# Altium schematic sheet DEVICE.SchDoc(DEVICE)
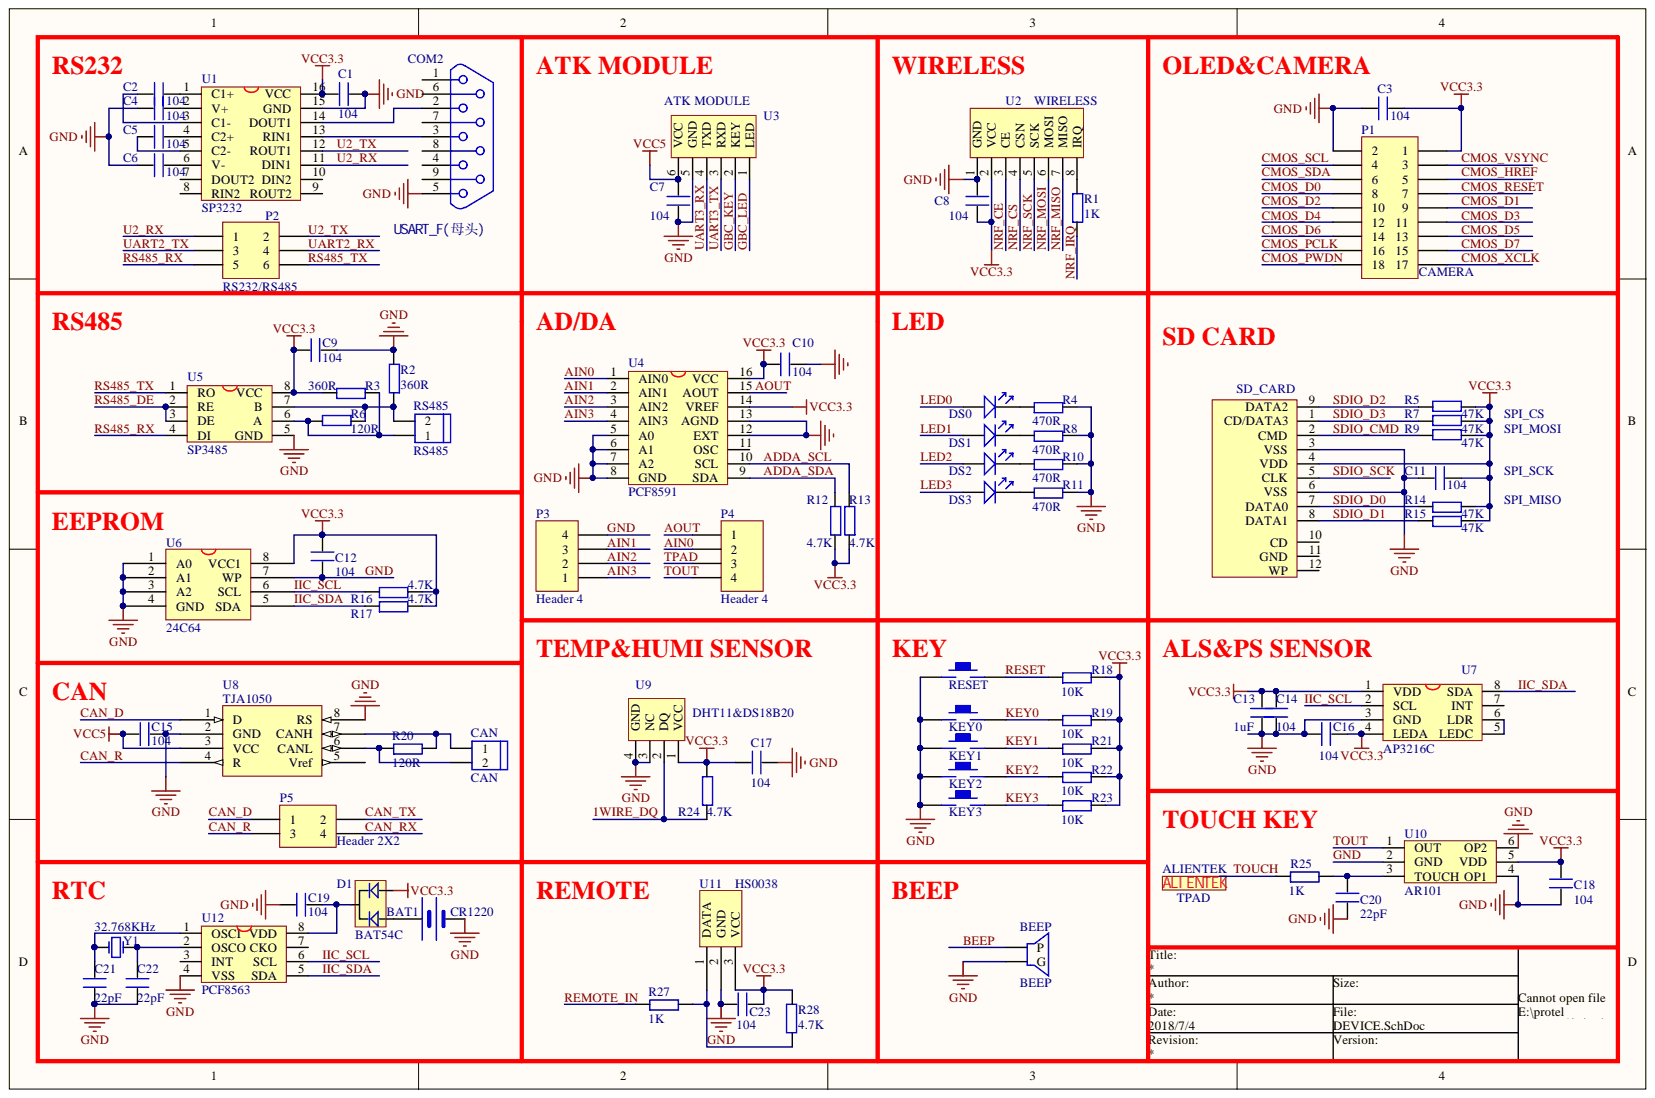
[diagram p0: DEVICE.SchDoc]

## Parts
BAT1  CR1220  [BATM]
BEEP  BEEP  [BELL-5]
C1  104  [0603P]
C2  104  [0603P]
C3  104  [0603P]
C4  104  [0603P]
C5  104  [0603P]
C6  104  [0603P]
C7  104  [0603P]
C8  104  [0603P]
C9  104  [0603P]
C10  104  [0603P]
C11  104  [0603P]
C12  104  [0603P]
C13  1uF  [0603P]
C14  104  [0603P]
C15  104  [0603P]
C16  104  [0603P]
C17  104  [0603P]
C18  104  [0603P]
C19  104  [0603P]
C20  22pF  [0603P]
C21  22pF  [0603P]
C22  22pF  [0603P]
C23  104  [0603P]
CAN  CAN  [HEAD2L-0.8]
COM2  USART_F(母头)  [DB9]
D1  BAT54C  [SOT-23]
KEY0  KEY_M  [KEY_M]  (value not drawn)
KEY1  KEY_M  [KEY_M]  (value not drawn)
KEY2  KEY_M  [KEY_M]  (value not drawn)
KEY3  KEY_M  [KEY_M]  (value not drawn)
LED1  DS0  [SMDLED-0805]
LED2  DS1  [SMDLED-0805]
LED3  DS2  [SMDLED-0805]
LED4  DS3  [SMDLED-0805]
P1  CAMERA  [HDR2X9]
P2  RS232/RS485  [HDR2X3]
P3  Header 4  [HDR1X4]
P4  Header 4  [HDR1X4]
P5  Header 2X2  [HDR2X2]
R1  1K  [0603P]
R2  360R  [0603P]
R3  360R  [0603P]
R4  470R  [0603P]
R5  47K  [0603P]
R6  120R  [0603P]
R7  47K  [0603P]
R8  470R  [0603P]
R9  47K  [0603P]
R10  470R  [0603P]
R11  470R  [0603P]
R12  4.7K  [0603P]
R13  4.7K  [0603P]
R14  47K  [0603P]
R15  47K  [0603P]
R16  4.7K  [0603P]
R17  4.7K  [0603P]
R18  10K  [0603P]
R19  10K  [0603P]
R20  120R  [0603P]
R21  10K  [0603P]
R22  10K  [0603P]
R23  10K  [0603P]
R24  4.7K  [0603P]
R25  1K  [0603P]
R27  1K  [0603P]
R28  4.7K  [0603P]
RESET  KEY_M  [KEY_M]  (value not drawn)
RS485  RS485  [HEAD2L-0.8]
SD_CARD  sd card  [SDCARD_L]  (value not drawn)
TPAD  ALIENTEK  [ALIENTEK_PAD1]
U1  SP3232  [SOP16M]
U2  WIRELESS  [NRF24L01]
U3  ATK MODULE  [HEAD6]
U4  PCF8591  [SO-16]
U5  SP3485  [SOP-8]
U6  24C64  [SOP-8]
U7  AP3216C  [QFN8]
U8  TJA1050  [SOP-8]
U9  DHT11&DS18B20  [DHT11]
U10  AR101  [SOT-23-6]
U11  HS0038  [HS0038]
U12  PCF8563  [SOP-8]
Y1  32.768KHz  [XTAL32]

## Nets
VCC3.3: C1.1 C3.1 C8.2 C9.2 C10.1 C11.2 C12.2 C13.1 C14.1 C16.2 C17.1 C18.1 C23.1 D1.2 P1.1 R3.1 R5.1 R7.1 R9.1 R12.1 R13.1 R14.2 R15.2 R16.2 R17.2 R18.2 R19.2 R21.2 R22.2 R23.2 R24.2 R28.1 SD_CARD.4(VDD) U1.16(VCC) U2.2(VCC) U4.14(VREF) U4.16(VCC) U5.8(VCC) U6.8(VCC1) U7.1(VDD) U7.4(LEDA) U9.1(VCC) U10.5(VDD) U11.3
VCC5: C7.1 C15.1 U3.6(VCC) U8.3(VCC)
1WIRE_DQ: R24.1 U9.2(DQ)
ADDA_SCL: R13.2 U4.10(SCL)
ADDA_SDA: R12.2 U4.9(SDA)
AIN0: P4.2 U4.1(AIN0)
AIN1: P3.3 U4.2(AIN1)
AIN2: P3.2 U4.3(AIN2)
AIN3: P3.1 U4.4(AIN3)
AOUT: P4.1 U4.15(AOUT)
BEEP: BEEP.1
CAN_D: P5.1 U8.1(D)
CAN_R: P5.3 U8.4(R)
CAN_RX: P5.4
CAN_TX: P5.2
CMOS_D0: P1.8
CMOS_D1: P1.9
CMOS_D2: P1.10
CMOS_D3: P1.11
CMOS_D4: P1.12
CMOS_D5: P1.13
CMOS_D6: P1.14
CMOS_D7: P1.15
CMOS_HREF: P1.5
CMOS_PCLK: P1.16
CMOS_PWDN: P1.18
CMOS_RESET: P1.7
CMOS_SCL: P1.4
CMOS_SDA: P1.6
CMOS_VSYNC: P1.3
CMOS_XCLK: P1.17
GBC_KEY: U3.2(KEY)
GBC_LED: U3.1(LED)
GND: BAT1.2 BEEP.2 C1.2 C3.2 C4.2 C6.1 C7.2 C8.1 C9.1 C10.2 C11.1 C12.1 C13.2 C14.2 C15.2 C16.1 C17.2 C18.2 C19.2 C20.1 C21.2 C22.2 C23.2 COM2.5 KEY0.1 KEY1.1 KEY2.1 KEY3.1 P1.2 P3.4 R2.2 R4.2 R8.2 R10.2 R11.2 RESET.1 SD_CARD.3(VSS) SD_CARD.6(VSS) U1.15(GND) U2.1(GND) U3.5(GND) U4.5(A0) U4.6(A1) U4.7(A2) U4.8(GND) U4.12(EXT) U4.13(AGND) U5.5(GND) U6.1(A0) U6.2(A1) U6.3(A2) U6.4(GND) U6.7(WP) U7.3(GND) U8.2(GND) U8.8(RS) U9.3(NC) U9.4(GND) U10.2(GND) U10.4(OP1) U10.6(OP2) U11.2 U12.4(VSS)
IIC_SCL: R16.1 U6.6(SCL) U7.2(SCL) U12.6(SCL)
IIC_SDA: R17.1 U6.5(SDA) U7.8(SDA) U12.5(SDA)
KEY0: KEY0.2 R19.1
KEY1: KEY1.2 R21.1
KEY2: KEY2.2 R22.1
KEY3: KEY3.2 R23.1
LED0: LED1.1
LED1: LED2.1
LED2: LED3.1
LED3: LED4.1
NRF_CE: U2.3(CE)
NRF_CS: U2.4(CSN)
NRF_IRQ: R1.1
NRF_MISO: U2.7(MISO)
NRF_MOSI: U2.6(MOSI)
NRF_SCK: U2.5(SCK)
REMOTE_IN: R27.1
RESET: R18.1 RESET.2
RS485_DE: U5.2(RE) U5.3(DE)
RS485_RX: P2.5 U5.4(DI)
RS485_TX: P2.6 U5.1(RO)
SDIO_CMD: R9.2 SD_CARD.2(CMD)
SDIO_D0: R14.1 SD_CARD.7(DATA0)
SDIO_D1: R15.1 SD_CARD.8(DATA1)
SDIO_D2: R5.2 SD_CARD.9(DATA2)
SDIO_D3: R7.2 SD_CARD.1(CD/DATA3)
SDIO_SCK: SD_CARD.5(CLK)
TOUCH: R25.1
TOUT: P4.4 U10.1(OUT)
TPAD: P4.3
U2_RX: P2.1 U1.11(DIN1)
U2_TX: P2.2 U1.12(ROUT1)
UART2_RX: P2.4
UART2_TX: P2.3
UART3_RX: U3.4(TXD)
UART3_TX: U3.3(RXD)
NetBAT1_1: BAT1.1 D1.1
NetC2_1: C2.1 U1.1(C1+)
NetC2_2: C2.2 U1.3(C1-)
NetC4_1: C4.1 U1.2(V+)
NetC5_1: C5.1 U1.5(C2-)
NetC5_2: C5.2 U1.4(C2+)
NetC6_2: C6.2 U1.6(V-)
NetC19_1: C19.1 D1.3 U12.8(VDD)
NetC20_2: C20.2 R25.2 U10.3(TOUCH)
NetC21_1: C21.1 U12.1(OSCI) Y1.2
NetC22_1: C22.1 U12.2(OSCO) Y1.1
NetCAN_1: CAN.1 R20.2 U8.7(CANH)
NetCAN_2: CAN.2 R20.1 U8.6(CANL)
NetCOM2_2: COM2.2 U1.14(DOUT1)
NetCOM2_3: COM2.3 U1.13(RIN1)
NetLED1_2: LED1.2 R4.1
NetLED2_2: LED2.2 R8.1
NetLED3_2: LED3.2 R10.1
NetLED4_2: LED4.2 R11.1
NetR1_2: R1.2 U2.8(IRQ)
NetR2_1: R2.1 R6.1 RS485.2 U5.7(B)
NetR3_2: R3.2 R6.2 RS485.1 U5.6(A)
NetR27_2: R27.2 R28.2 U11.1
NetU7_5: U7.5(LEDC) U7.6(LDR)

Not connected: COM2 1 4 6 7 8 9; SD_CARD 10(CD) 11(GND) 12(WP); U1 7(DOUT2) 8(RIN2) 9(ROUT2) 10(DIN2); U4 11(OSC); U7 7(INT); U8 5(Vref); U12 3(INT) 7(CKO)

## Library data of the parts
BAT1 = BAT: Library Name: ALIENTEK_COMPONENT.IntLib; Component Kind: Standard
C1-C23 = C: Library Name: MYCOMLIB.IntLib; Component Kind: Standard
CAN RS485 = HEAD2: Library Name: ALIENTEK_COMPONENT.IntLib; Component Kind: Standard
COM2 = DB9: Library Name: MYCOMLIB.IntLib; Component Kind: Standard
D1 = BAT54C: 双肖特基二极管; Library Name: ALIENTEK_COMPONENT.IntLib; Component Kind: Standard
LED1-LED4 = LED: Library Name: ALIENTEK_COMPONENT.IntLib; Component Kind: Standard
P1 = Header 9X2: Header, 9-Pin, Dual row; Library Name: Miscellaneous Connectors.IntLib; LatestRevisionNote: Re-released for DXP Platform.; LatestRevisionDate: 17-Jul-2002; Component Kind: Standard; Publisher: Altium Limited
P2 = Header 3X2: Header, 3-Pin, Dual row; Library Name: Miscellaneous Connectors.IntLib; LatestRevisionNote: Re-released for DXP Platform.; LatestRevisionDate: 17-Jul-2002; Component Kind: Standard; Publisher: Altium Limited
P3 P4 = Header 4: Header, 4-Pin; Signal Integrity: Connector; Library Name: Miscellaneous Connectors.IntLib; LatestRevisionNote: Re-released for DXP Platform.; LatestRevisionDate: 17-Jul-2002; Component Kind: Standard; Publisher: Altium Limited
P5 = Header 2X2: Header, 2-Pin, Dual row; Signal Integrity: Connector; Library Name: Miscellaneous Connectors.IntLib; LatestRevisionNote: Re-released for DXP Platform.; LatestRevisionDate: 17-Jul-2002; Component Kind: Standard; Publisher: Altium Limited
R1-R25 R27 R28 = R: Library Name: MYCOMLIB.IntLib; Component Kind: Standard
TPAD = TPAD: ALIENTEK TPAD; Library Name: ALIENTEK_COMPONENT.IntLib; Component Kind: Standard
U1 = MAX3232: Library Name: MYCOMLIB.IntLib; Component Kind: Standard
U2 = NRF24L01: Library Name: MYCOMLIB.IntLib; Component Kind: Standard
U3 = ATK-HC05: Library Name: ALIENTEK_COMPONENT.IntLib; Description: ATK-HC05; Component Kind: Standard
U4 = PCF8591: 4路AD+1路DA芯片.; Library Name: ALIENTEK_COMPONENT.IntLib; Component Kind: Standard
U5 = MAX3485: Library Name: MYCOMLIB.IntLib; Component Kind: Standard
U6 = 24C256: Library Name: MYCOMLIB.IntLib; Component Kind: Standard
U7 = AP3216C: ALS+PS Sensor; Library Name: ALIENTEK_COMPONENT.IntLib; Component Kind: Standard
U8 = SN65HVD230D: Component Kind: Standard
U9 = DHT11: 数字温湿度传感器; Library Name: ALIENTEK_COMPONENT.IntLib; Component Kind: Standard
U10 = AR101: 单路电容触摸芯片; Library Name: ALIENTEK_COMPONENT.IntLib; Component Kind: Standard
U12 = PCF8563: 时钟芯片; Library Name: ALIENTEK_COMPONENT.IntLib; Component Kind: Standard
Y1 = XTAL: 普通晶振; Published: 8-Jun-2000; Library Name: ALIENTEK_COMPONENT.IntLib; LatestRevisionNote: Re-released for DXP Platform.; LatestRevisionDate: 17-Jul-2002; Component Kind: Standard; Publisher: Altium Limited
BEEP = BEEP: Library Name: ALIENTEK_COMPONENT.IntLib; Component Kind: Standard
KEY0-KEY3 RESET = KEY_M: Library Name: ALIENTEK_COMPONENT.IntLib; Component Kind: Standard
SD_CARD = sd card: Library Name: MYCOMLIB.IntLib; Component Kind: Standard
U11 = HS0038: Component Kind: Standard; Library Name: selfmade.SchLib
